# Altium schematic sheet chiet_rot_aruino.SchDoc
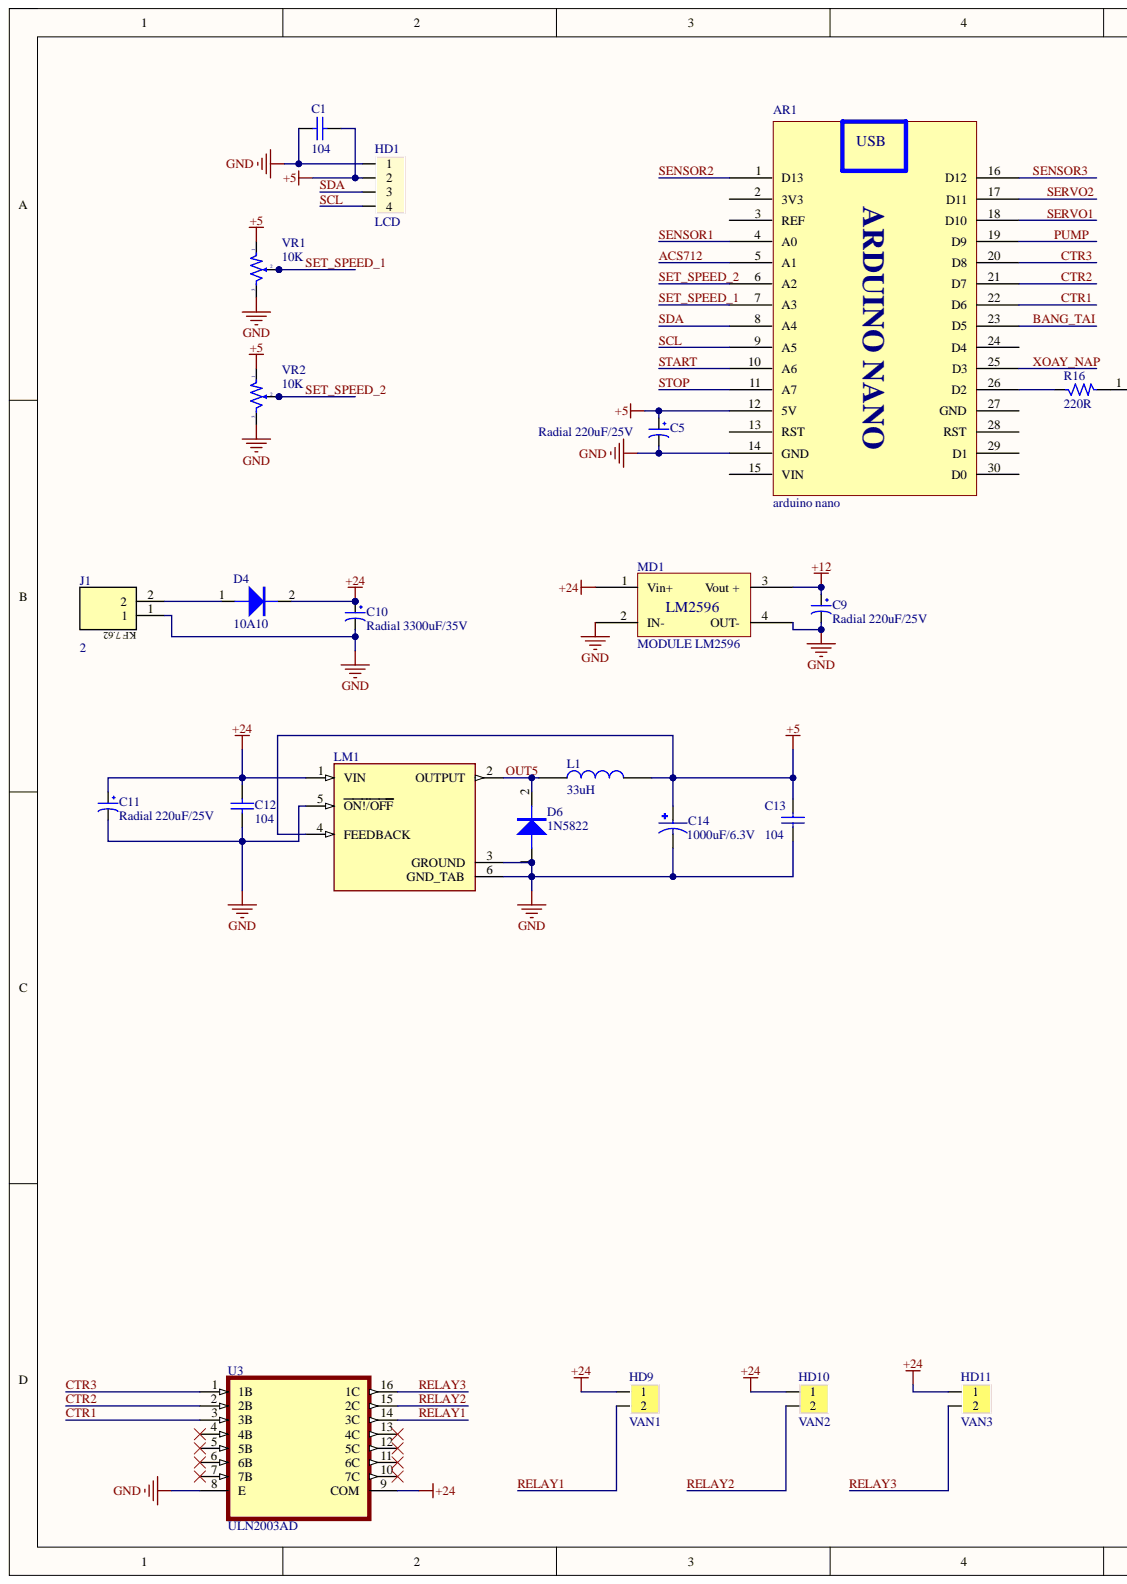
[diagram p0: chiet_rot_aruino.SchDoc, left]
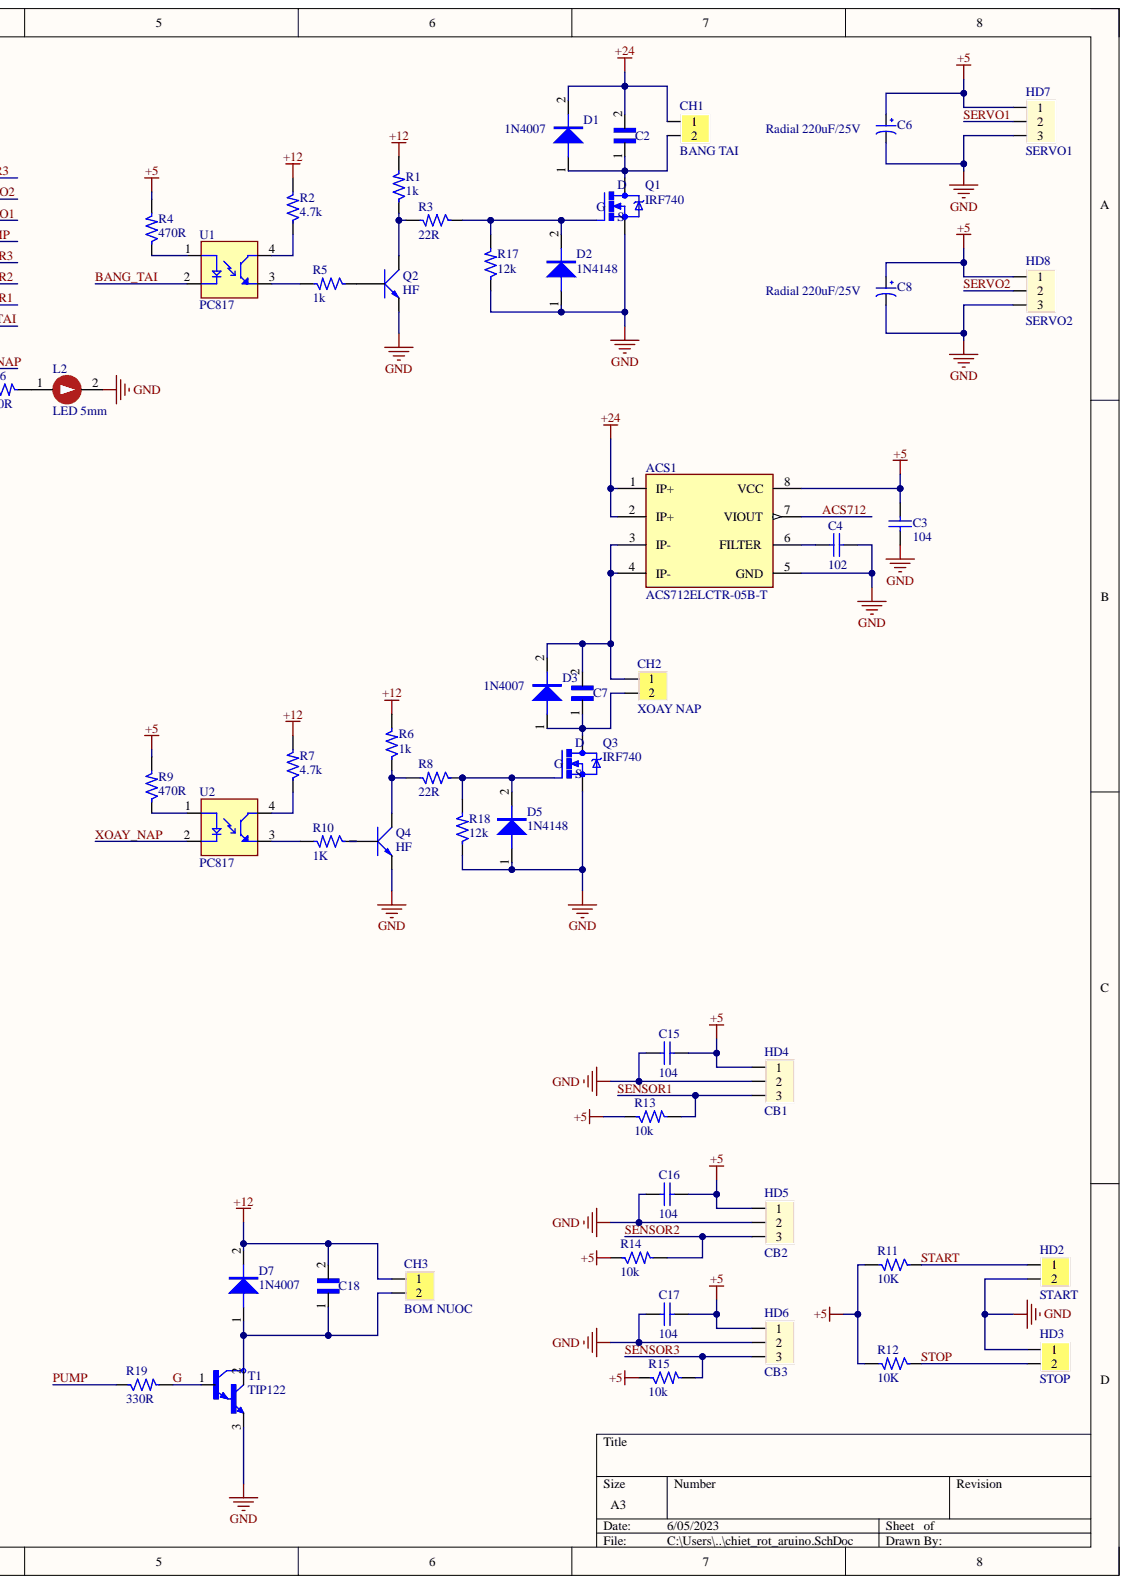
[diagram p1: chiet_rot_aruino.SchDoc, right]

## Parts
ACS1  ACS712ELCTR-05B-T  [LM358SMD]
AR1  arduino nano  [arduino nano]
C1  104  [Cap Ceramic 0805]
C2  ECQE6153KF  [CAP FILM 7.5mm]  (value not drawn)
C3  104  [Cap Ceramic 0805]
C4  102  [Cap Ceramic 0805]
C5  Radial 220uF/25V  [Radial 6.3x12mm]
C6  Radial 220uF/25V  [Radial 6.3x12mm]
C7  ECQE6153KF  [CAP FILM 7.5mm]  (value not drawn)
C8  Radial 220uF/25V  [Radial 6.3x12mm]
C9  Radial 220uF/25V  [Radial 6.3x12mm]
C10  Radial 3300uF/35V  [Radial 16x25mm]
C11  Radial 220uF/25V  [Radial 6.3x12mm]
C12  104  [Cap Ceramic 0805]
C13  104  [Cap Ceramic 0805]
C14  1000uF/6.3V  [Radial 8x12mm]
C15  104  [Cap Ceramic 0805]
C16  104  [Cap Ceramic 0805]
C17  104  [Cap Ceramic 0805]
C18  ECQE6153KF  [CAP FILM 7.5mm]  (value not drawn)
CH1  BANG TAI  [Header CH 2P 3,96]
CH2  XOAY NAP  [Header CH 2P 3,96]
CH3  BOM NUOC  [Header CH 2P 3,96]
D1  1N4007  [SMA]
D2  1N4148  [SOD-123]
D3  1N4007  [SMA]
D4  10A10  [diode 10A]
D5  1N4148  [SOD-123]
D6  1N5822  [SMA]
D7  1N4007  [SMA]
HD1  LCD  [Header 4P]
HD2  START  [Header 2P]
HD3  STOP  [Header 2P]
HD4  CB1  [Header 3P]
HD5  CB2  [Header 3P]
HD6  CB3  [Header 3P]
HD7  SERVO1  [Header 3P]
HD8  SERVO2  [Header 3P]
HD9  VAN1  [Header 2P]
HD10  VAN2  [Header 2P]
HD11  VAN3  [Header 2P]
J1  2  [KF7.62_2P]
L1  33uH  [INDUCTOR 13x8x5]
L2  LED 5mm  [LED-5MM-RED]
LM1  SIMPLE SWITCHER&reg; 4.5V to 40V, 3A Low Component Count Step-Down Regulator 5-DDPAK/TO-263  [LM2596 - 5V]  (value not drawn)
MD1  MODULE LM2596  [MODULE LM2596]
Q1  IRF740  [TO220BV]
Q2  HF  [SOT23]
Q3  IRF740  [TO220BV]
Q4  HF  [SOT23]
R1  1k  [Res_0805]
R2  4.7k  [Res_0805]
R3  22R  [Res_0805]
R4  470R  [Res_0805]
R5  1k  [Res_0805]
R6  1k  [Res_0805]
R7  4.7k  [Res_0805]
R8  22R  [Res_0805]
R9  470R  [Res_0805]
R10  1K  [Res_0805]
R11  10K  [Res_0805]
R12  10K  [Res_0805]
R13  10k  [Res_0805]
R14  10k  [Res_0805]
R15  10k  [Res_0805]
R16  220R  [Res_0805]
R17  12k  [Res_0805]
R18  12k  [Res_0805]
R19  330R  [Res_0805]
T1  TIP122  [TO-220]
U1  PC817  [PC817 DIP]
U2  PC817  [PC817 DIP]
U3  ULN2003AD  [DIP16]
VR1  10K  [Bien Tro Volume Don]
VR2  10K  [Bien Tro Volume Don]

## Nets
+5: ACS1.8(VCC) AR1.12(5V) C1.2 C3.2 C5.1 C6.1 C8.1 C13.2 C14.1 C15.2 C16.2 C17.2 HD1.2 HD4.1 HD5.1 HD6.1 HD7.1 HD8.1 L1.2 LM1.4(FEEDBACK) R4.2 R9.2 R11.2 R12.2 R13.1 R14.1 R15.1 VR1.1 VR2.1
+12: C9.1 C18.2 CH3.1 D7.2 MD1.3(Vout_+) R1.2 R2.2 R6.2 R7.2
+24: ACS1.1(IP+) ACS1.2(IP+) C2.2 C10.1 C11.1 C12.2 CH1.1 D1.2 D4.2 HD9.1 HD10.1 HD11.1 LM1.1(VIN) MD1.1(Vin+) U3.9(COM)
GND: ACS1.5(GND) AR1.14(GND) C1.1 C3.1 C4.1 C5.2 C6.2 C8.2 C9.2 C10.2 C11.2 C12.1 C13.1 C14.2 C15.1 C16.1 C17.1 D2.1 D5.1 D6.1 HD1.1 HD2.2 HD3.1 HD4.2 HD5.2 HD6.2 HD7.3 HD8.3 J1.1 L2.2 LM1.3(GROUND) LM1.5(ON!/OFF) LM1.6(GND_TAB) MD1.2(IN-) MD1.4(OUT-) Q1.S Q2.2 Q3.S Q4.2 R17.1 R18.1 T1.3 U3.8(E) VR1.3 VR2.3
ACS712: ACS1.7(VIOUT) AR1.5(A1)
BANG_TAI: AR1.23(D5) U1.2
CTR1: AR1.22(D6) U3.3(3B)
CTR2: AR1.21(D7) U3.2(2B)
CTR3: AR1.20(D8) U3.1(1B)
G: R19.2 T1.1
OUT5: D6.2 L1.1 LM1.2(OUTPUT)
PUMP: AR1.19(D9) R19.1
RELAY1: HD9.2 U3.14(3C)
RELAY2: HD10.2 U3.15(2C)
RELAY3: HD11.2 U3.16(1C)
SCL: AR1.9(A5) HD1.4
SDA: AR1.8(A4) HD1.3
SENSOR1: AR1.4(A0) HD4.3 R13.2
SENSOR2: AR1.1(D13) HD5.3 R14.2
SENSOR3: AR1.16(D12) HD6.3 R15.2
SERVO1: AR1.18(D10) HD7.2
SERVO2: AR1.17(D11) HD8.2
SET_SPEED_1: AR1.7(A3) VR1.2
SET_SPEED_2: AR1.6(A2) VR2.2
START: AR1.10(A6) HD2.1 R11.1
STOP: AR1.11(A7) HD3.2 R12.1
XOAY_NAP: AR1.25(D3) U2.2
NetACS1_3: ACS1.3(IP-) ACS1.4(IP-) C7.2 CH2.1 D3.2
NetACS1_6: ACS1.6(FILTER) C4.2
NetAR1_26: AR1.26(D2) R16.1
NetC2_1: C2.1 CH1.2 D1.1 Q1.D
NetC7_1: C7.1 CH2.2 D3.1 Q3.D
NetC18_1: C18.1 CH3.2 D7.1 T1.2
NetD2_2: D2.2 Q1.G R3.1 R17.2
NetD4_1: D4.1 J1.2
NetD5_2: D5.2 Q3.G R8.1 R18.2
NetL2_1: L2.1 R16.2
NetQ2_1: Q2.1 R5.1
NetQ2_3: Q2.3 R1.1 R3.2
NetQ4_1: Q4.1 R10.1
NetQ4_3: Q4.3 R6.1 R8.2
NetR2_1: R2.1 U1.4
NetR4_1: R4.1 U1.1
NetR5_2: R5.2 U1.3
NetR7_1: R7.1 U2.4
NetR9_1: R9.1 U2.1
NetR10_2: R10.2 U2.3

Not connected: AR1 2(3V3) 3(REF) 13(RST) 15(VIN) 24(D4) 27(GND) 28(RST) 29(D1) 30(D0); U3 4(4B) 5(5B) 6(6B) 7(7B) 10(7C) 11(6C) 12(5C) 13(4C)

## Library data of the parts
C1 C3 C4 C12 C13 C15-C17 = CAP Ceramic SMD: Component Kind: Standard; Description: Cap Ceramic SMD; Library Name: KS Chuong.SchLib
C2 C7 C18 = CAP FILM 7.5mm: 10mm; Availability: Unavailable; Component Kind: Standard; MP: ECQE6153KF; Package: None; Price: None; Library Name: KS Chuong.SchLib
C14 = Radial 1000u/6.3V: CAP ALUM 1000UF 20% 6.3V RAD TH; MF: Panasonic; MP: EEUFK0J102; Availability: In Stock; Check_prices: https://www.snapeda.com/parts/EEUFK0J102/Panasonic/view-part/5787305/?ref=eda; Component Kind: Standard; Package: RADIAL-2 Panasonic; Price: None; Purchase-URL: https://pricing.snapeda.com/search/part/EEUFK0J102/?ref=eda; SnapEDA_Link: https://www.snapeda.com/parts/EEUFK0J102/Panasonic/view-part/5787305/?ref=snap; Library Name: KS Chuong.SchLib
CH1-CH3 = header CH 2P 3.96: Component Kind: Standard; Library Name: KS Chuong.SchLib
D1-D7 = DIODE SMD STANDARD: Component Kind: Standard; Library Name: KS Chuong.SchLib
HD1 = Header 4P: Value: Connector 4; Component Kind: Standard; Description: Header 4P; Library Name: KS Chuong.SchLib
HD2 HD3 HD9-HD11 = Header 2P: Component Kind: Standard; Description: Header 2P; Library Name: KS Chuong.SchLib
HD4-HD8 = Header 3P: Value: Connector 3; Component Kind: Standard; Description: Header 3P; Library Name: KS Chuong.SchLib
J1 = KF7.62_2P: BARRIE TERMINAL BLOCK; MF: On Shore; MP: OSTYK44104030; Availability: In Stock; Check_prices: https://www.snapeda.com/parts/OSTYK44104030/On+Shore+Technology+Inc./view-part/?ref=eda; Component Kind: Standard; Package: None; Price: None; Purchase-URL: https://pricing.snapeda.com/search/part/OSTYK44104030/?ref=eda; SnapEDA_Link: https://www.snapeda.com/parts/OSTYK44104030/On+Shore+Technology+Inc./view-part/?ref=snap; Library Name: KS Chuong.SchLib
L1 = INDUCTOR_DIP: High Current Toroidal Inductor | 390 uH; MF: Signal Transformer; MP: HCTI-390-5.0; Arrow_Electronics-Purchase-URL: https://snapeda.com/shop?store=Arrow+Electronics&id=1645901; Availability: Bad; Component Kind: Standard; DigiKey-Purchase-URL: https://snapeda.com/shop?store=DigiKey&id=1645901; Mouser-Purchase-URL: https://snapeda.com/shop?store=Mouser&id=1645901; Package: Radial Bel Fuse; Price: None; SnapEDA_Link: https://www.snapeda.com/parts/HCTI-390-5.0/Signal%20Transformer/view-part/1645901/?ref=snap; Library Name: KS Chuong.SchLib
L2 = LED 5mm Red: Cắm; Don_Vi: Con; Ma_Hang: MH008967; Ten_Linh_Kien: Led 5MM Phủ Đỏ (1000c); Chung_Loai: Cắm; Component Kind: Standard; Library Name: KS Chuong.SchLib
LM1 = LM2596S-5V: MF: Texas Instruments; MP: LM2596S-5.0/NOPB; Availability: Good; Check_prices: https://www.snapeda.com/parts/LM2596S-5.0/NOPB/Texas%20Instruments/view-part/15588/?ref=eda; Component Kind: Standard; Description: LM2596S-5V; DigiKey-Purchase-URL: https://snapeda.com/shop?store=DigiKey&id=15588; Mouser-Purchase-URL: https://snapeda.com/shop?store=Mouser&id=15588; Package: TO-263-5 Texas Instruments; Price: None; SnapEDA_Link: https://www.snapeda.com/parts/LM2596S-5.0/NOPB/Texas%20Instruments/view-part/15588/?ref=snap; Texas_Instruments-Purchase-URL: https://snapeda.com/shop?store=Texas+Instruments&id=15588; Library Name: KS Chuong.SchLib
Q1 Q3 = IRF740: N-Channel 400 V 10A (Tc) 3.1W (Ta), 125W (Tc) Surface Mount DÂ²PAK (TO-263); MF: Vishay; Availability: In Stock; Check_prices: https://www.snapeda.com/parts/IRF740/Vishay+Siliconix/view-part/?ref=eda; Component Kind: Standard; MP: IRF740; Package: TO-220-3 Vishay; Price: None; Purchase-URL: https://pricing.snapeda.com/search/part/IRF740/?ref=eda; SnapEDA_Link: https://www.snapeda.com/parts/IRF740/Vishay+Siliconix/view-part/?ref=snap; Library Name: KS Chuong.SchLib
Q2 Q4 = S8050: NPN transistor; Value: C9014; Component Kind: Standard; Library Name: KS Chuong.SchLib
R1-R19 = Res SMD: Component Kind: Standard; Library Name: KS Chuong.SchLib
T1 = TIP122: Bipolar _BJT_ Transistor NPN - Darlington 100V 5A 2W Through Hole TO-220AB; MF: ON Semiconductor; Availability: Unavailable; Component Kind: Standard; MP: TIP122; Package: TO-220 Fairchild Semiconductor; Price: None; SnapEDA_Link: https://www.snapeda.com/parts/TIP122/ON%20Semiconductor/view-part/315945/?ref=snap; Library Name: KS Chuong.SchLib
U1 U2 = PC817: Optoisolator Transistor Output 5000Vrms 1 Channel 4-DIP; MF: SHARP/Socle Technology; MP: PC817X1CSZ9F; Availability: In Stock; Check_prices: https://www.snapeda.com/parts/PC817X1CSZ9F/SHARP%252FSocle+Technology/view-part/?ref=eda; Component Kind: Standard; Package: DIP-4 SHARP/Socle Technology; Price: None; SnapEDA_Link: https://www.snapeda.com/parts/PC817X1CSZ9F/SHARP%252FSocle+Technology/view-part/?ref=snap; Library Name: KS Chuong.SchLib
VR1 VR2 = Potentiometer: Cắm; Don_Vi: Con; Ma_Hang: MH001417; Ten_Linh_Kien: Triết Áp Đơn B10K; Chung_Loai: Cắm; Component Kind: Standard; Library Name: KS Chuong.SchLib
ACS1 = ACS712ELCTR-05B-T: Component Kind: Standard; Library Name: KS Chuong.SchLib
AR1 = arduino nano: Component Kind: Standard; Library Name: KS Chuong.SchLib
C5 C6 C8 C9 C11 = Radial 220uF/25V: Component Kind: Standard; Description: Radial 220uF/25V; Library Name: KS Chuong.SchLib
C10 = Radial 3300uF/35V: Component Kind: Standard; Description: Radial 3300uF/35V; Library Name: KS Chuong.SchLib
MD1 = MODULE LM2596: Component Kind: Standard; Library Name: KS Chuong.SchLib
U3 = ULN2003AD: Availability: Unavailable; Component Kind: Standard; MP: ULN2003AD; Package: None; Price: None; Library Name: KS Chuong.SchLib
